# Altium schematic sheet Controller.SchDoc
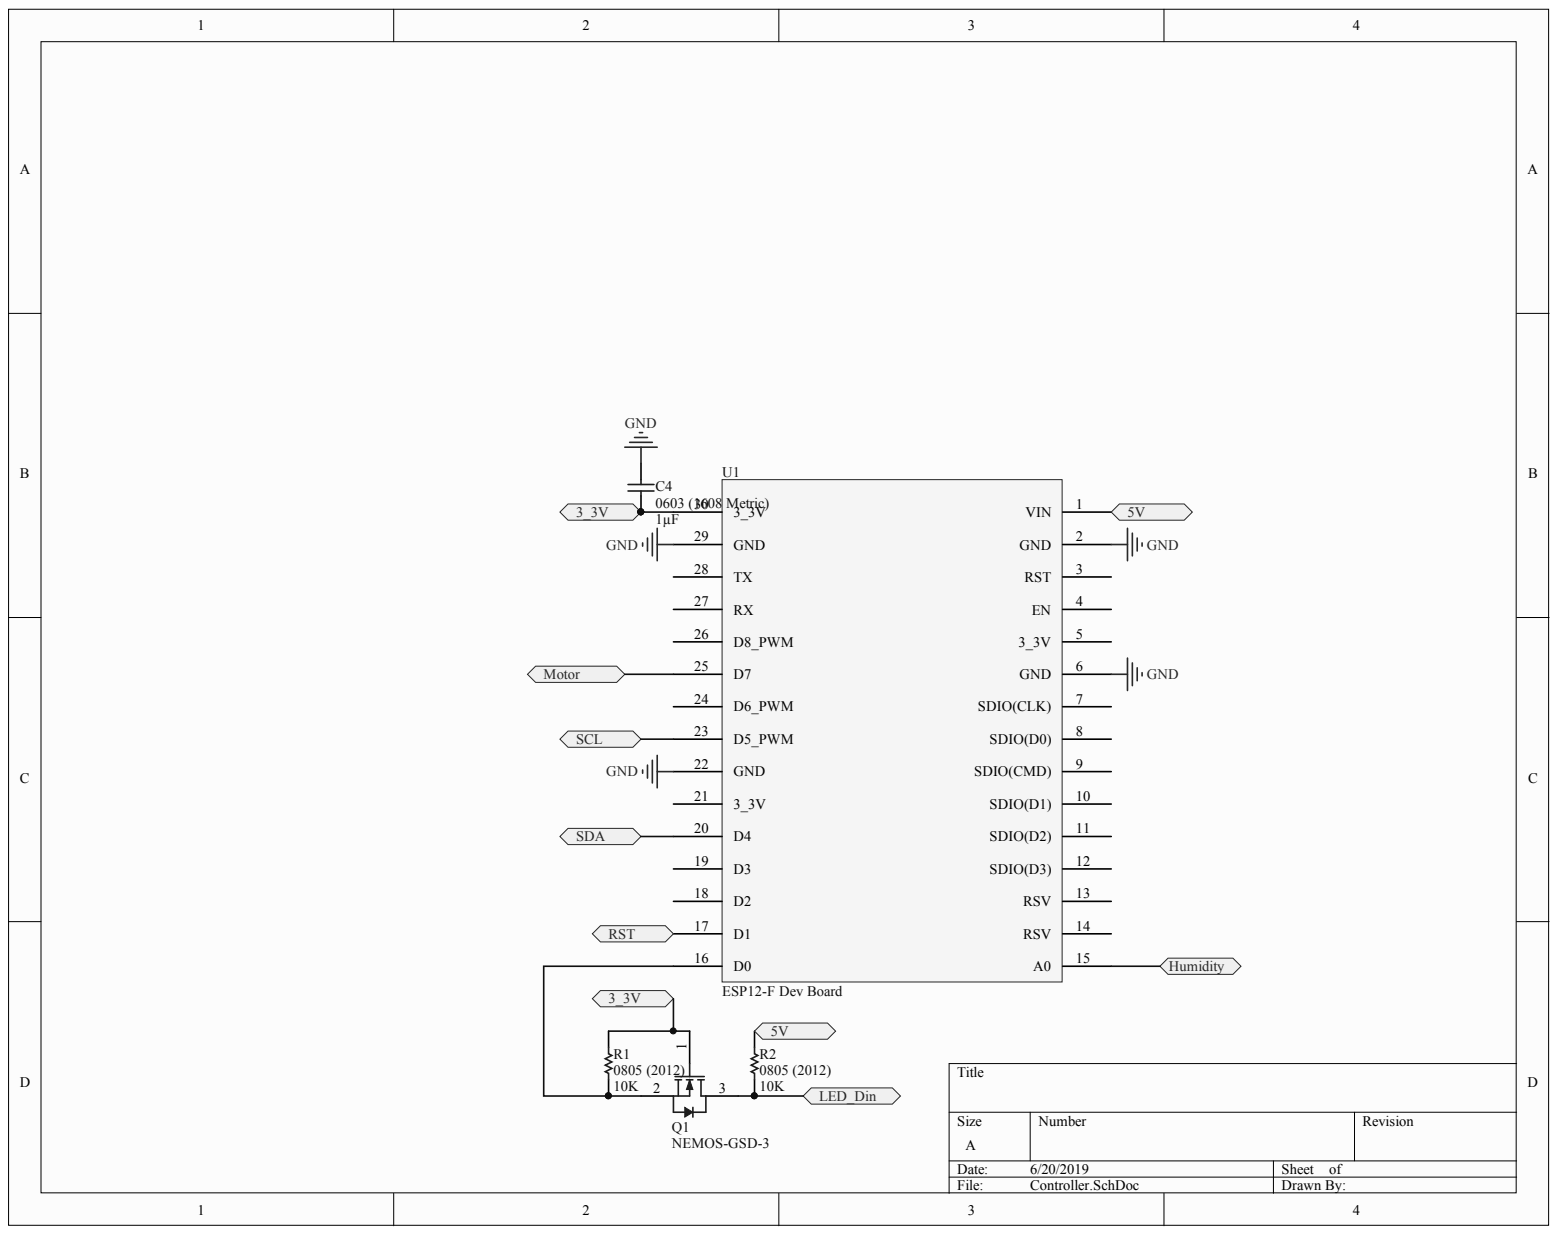
[diagram p0: Controller.SchDoc]

## Parts
C4  Capacitance: 1µF  [PCB-lkmleyt60a11wkc2qm7q-1]  (hidden comment: Samsung CL10A105KP8NNNC)
Q1  NEMOS-GSD-3  [PCB-dbhk9q13wds7exg38ggf-1]
R1  0805 (2012)  Resistance: 10K  [PCB-m3d1bui9az782fo214h9-1]
R2  0805 (2012)  Resistance: 10K  [PCB-m3d1bui9az782fo214h9-1]
U1  ESP12-F Dev Board  [PCB-z8aaw0yugxv87lcq77q0-1]

## Ports
3_3V, 5V, HUMIDITY, LED_DIN, MOTOR, RST, SCL, SDA

## Nets
GND: C4.2 U1.2(GND) U1.6(GND) U1.22(GND) U1.29(GND)
HUMIDITY: U1.15(A0) ; port HUMIDITY
LED_DIN: Q1.3 R2.1 ; port LED_DIN
MOTOR: U1.25(D7) ; port MOTOR
3_3V: C4.1 Q1.1 R1.2 U1.30(3_3V) ; port 3_3V
5V: R2.2 U1.1(VIN) ; port 5V
RST: U1.17(D1) ; port RST
SCL: U1.23(D5_PWM) ; port SCL
SDA: U1.20(D4) ; port SDA
NetQ1_2: Q1.2 R1.1 U1.16(D0)

Not connected: U1 3(RST) 4(EN) 5(3_3V) 7(SDIO(CLK)) 8(SDIO(D0)) 9(SDIO(CMD)) 10(SDIO(D1)) 11(SDIO(D2)) 12(SDIO(D3)) 13(RSV) 14(RSV) 18(D2) 19(D3) 21(3_3V) 24(D6_PWM) 26(D8_PWM) 27(RX) 28(TX)

## Library data of the parts
C4 = CMP-1956d172a695c691-3: CL10 Series 1 uF 10 V ±10 % Tolerance X5R SMT Multilayer Ceramic Capacitor; Manufacturer: Samsung Electro-Mechanics; Vendor Part Number: 1276-1182-1-ND; Tolerance: ±10%; Vendor: Digikey; Voltage - Rated: 10V; Applications: General Purpose; Component Kind: Standard; Cost: 0.10; HelpURL: http://www.samsungsem.com/kr/support/product-search/mlcc/__icsFiles/afieldfile/2016/08/18/S_CL10A105KP8NNNC.pdf; Library Name: CommunityVault; Manufacturer PArt Number: CL10A105KP8NNNC; Mounting Type: Surface Mount, MLCC; Operating Temperature: -55°C ~ 85°C; Package / Case: 0603 (1608 Metric); Series: CL; Size / Dimension: 0.063" L x 0.032" W (1.60mm x 0.80mm); Temperature Coefficient: X5R; Thickness (Max): 0.035" (0.90mm)
Q1 = CMP-2868e1aa5c2af7af-8: Transistor NPN Mos BSS138 FAIRCHILD RoHS miliampere=220 V=50 SOt23; Manufacturer: Fairchild Semiconductor; Breakdown Voltage (Drain to Source): 50.0 V; Breakdown Voltage [Gate to Source]: -20.0 V to 20.0 V; Continuous Drain Current (Ids): 220 mA; Drain to Source Resistance (on) (Rds): 3.50 Ω; Input Capacitance: 27.0 pF; Lead-Free Status: Lead Free; Polarity: N-Channel; Case/Package: SOT-23; Component Kind: Standard; Library Name: CommunityVault; Lifecycle Status: Active; Manufacturer Part Number: BSS138; Number of Pins: 3; Octopart URL: http://octopart.com/bss138-fairchild+semiconductor-13127972; Packaging: Cut Tape (CT), Tape & Reel (TR); Voltage Rating (DC): 50.0 V
R1 R2 = CMP-wcd0num848d6j3qzthhk-1: Generic Resistor pad 0805 Imperial and 2012 Metric; Case/Package: 0805 (2012); Component Kind: Standard; CustomComponentID: wcd0num848d6j3qzthhk; Library Name: CommunityVault
U1 = CMP-sxw3ufcwmxs35hbw3bea-6: Board for the ESP12-F module made by Doit.am; Component Kind: Standard; CustomComponentID: sxw3ufcwmxs35hbw3bea; Homepage URL: https://github.com/SmartArduino/SZDOITWiKi/wiki/ESP8266---ESP-F; Library Name: FavoritesLibrary; Manufacturer: Doit.am
